# Altium schematic sheet 20-002314-00 Pg6 Interface 2.SchDoc
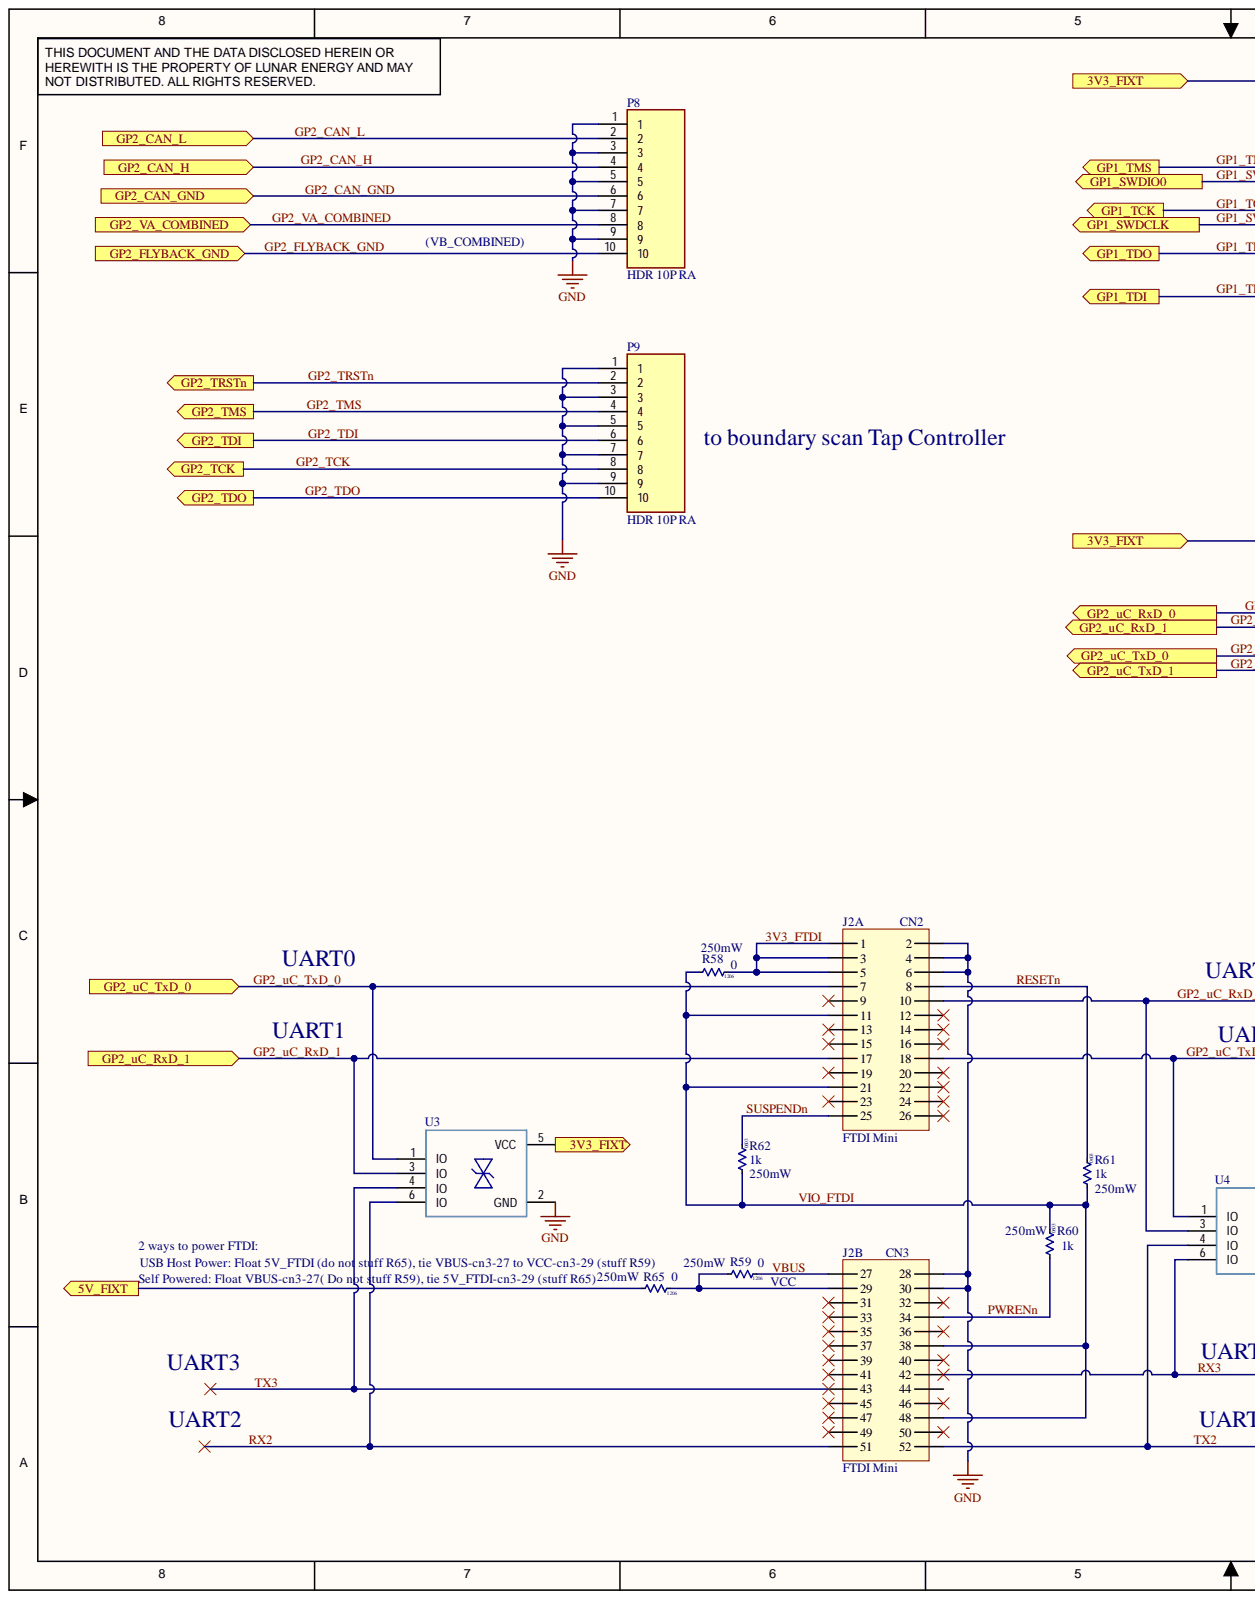
[diagram p0: 20-002314-00 Pg6 Interface 2.SchDoc, left]
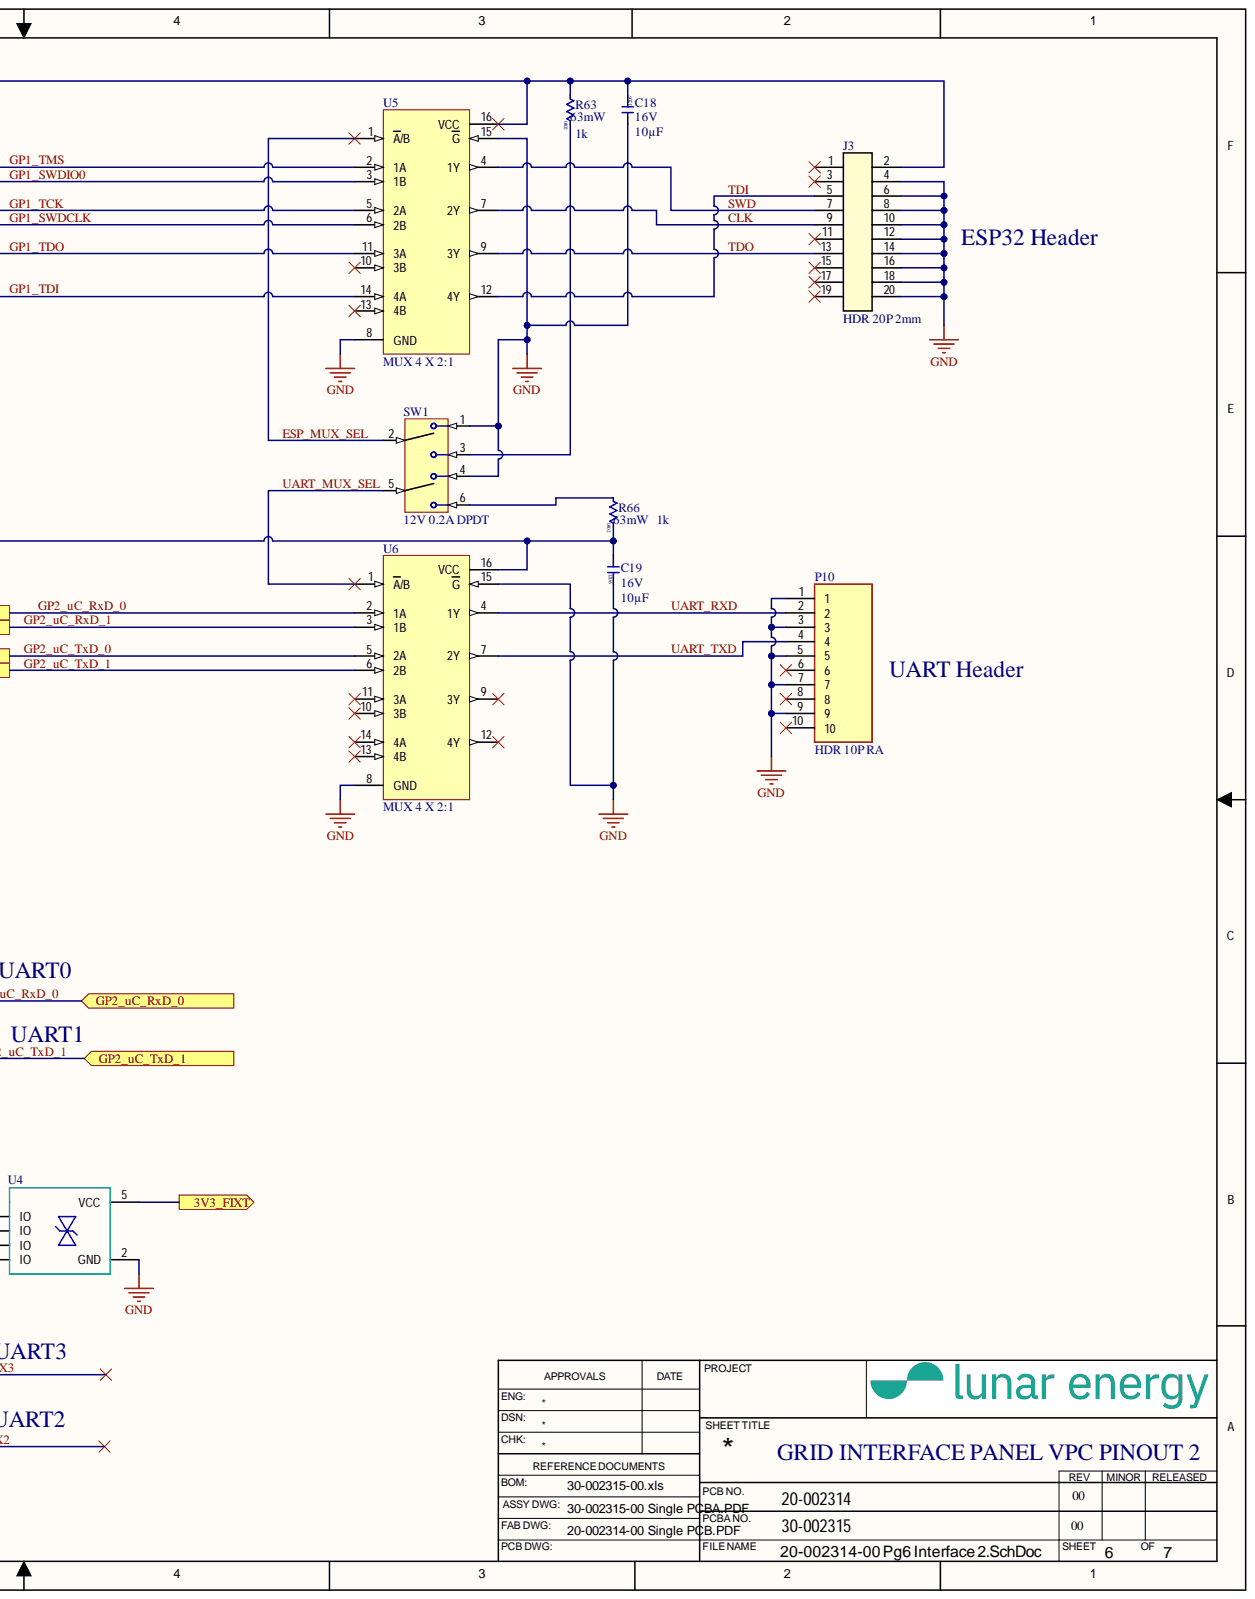
[diagram p1: 20-002314-00 Pg6 Interface 2.SchDoc, right]

## Parts
C18  value 10µF  Rated DC Voltage (URdc): 16V  Size Code: 1206  [CAPC1206 - Lunar]  (hidden comment: CNC5L1X7R1C106K160AE)
C19  value 10µF  Rated DC Voltage (URdc): 16V  Size Code: 1206  [CAPC1206 - Lunar]  (hidden comment: CNC5L1X7R1C106K160AE)
J2  Lunar Sym Name: FTDI Mini  [FT4232H MINI MODULE]  (hidden comment: FT4232H MINI MODULE)  (parts A,B)
J3  Lunar Sym Name: HDR 20P 2mm  [CONN HDR 20P 2mm-Footprint-1]  (hidden comment: CONN HDR 20P 2mm)
P8  Lunar Sym Name: HDR 10P RA  [CONN HDR RA 10POS 2.54MM]  (hidden comment: CONN HDR RA 10POS 2.54MM)
P9  Lunar Sym Name: HDR 10P RA  [CONN HDR RA 10POS 2.54MM]  (hidden comment: CONN HDR RA 10POS 2.54MM)
P10  Lunar Sym Name: HDR 10P RA  [CONN HDR RA 10POS 2.54MM]  (hidden comment: CONN HDR RA 10POS 2.54MM)
R58  value 0  Case/Package: 1206  Power: 250mW  [RES1206 - Lunar]  (hidden comment: RMCF1206ZT0R00)
R59  value 0  Case/Package: 1206  Power: 250mW  [RES1206 - Lunar]  (hidden comment: RMCF1206ZT0R00)
R60  value 1k  Rated Power Dissipation (P): 250mW  Resistance: 1k  Size Code: 0603  [R template test-Footprint-1]  (hidden comment: R 0603 1K OHM 1/4W)
R61  value 1k  Rated Power Dissipation (P): 250mW  Resistance: 1k  Size Code: 0603  [R template test-Footprint-1]  (hidden comment: R 0603 1K OHM 1/4W)
R62  value 1k  Rated Power Dissipation (P): 250mW  Resistance: 1k  Size Code: 0603  [R template test-Footprint-1]  (hidden comment: R 0603 1K OHM 1/4W)
R63  value 1k  Case/Package: 0402  Rated Power Dissipation (P): 63mW  Resistance: 1k  [RES 0402 - Lunar]  (hidden comment: R 0402 1K OHM 1/16W)
R65  value 0  Case/Package: 1206  Power: 250mW  [RES1206 - Lunar]  (hidden comment: RMCF1206ZT0R00)
R66  value 1k  Case/Package: 0402  Rated Power Dissipation (P): 63mW  [RES 0402 - Lunar]  (hidden comment: R 0402 1K OHM 1/16W)
SW1  Lunar Sym Name: 12V 0.2A DPDT  Throw Configuration: DPDT  [SWITCH SLIDE DPDT 200MA 12V-Footprint-1]  (hidden comment: SWITCH SLIDE DPDT 200MA 12V)
U3  DT1446  [TSOT26]  (value not drawn)
U4  DT1446  [TSOT26]  (value not drawn)
U5  Lunar Sym Name: MUX 4 X 2:1  [DB0016A_M]  (hidden comment: IC MUX 4 X 2:1)
U6  Lunar Sym Name: MUX 4 X 2:1  [DB0016A_M]  (hidden comment: IC MUX 4 X 2:1)

## Ports
3V3_FIXT, 5V_FIXT, GP1_SWDCLK, GP1_SWDIO0, GP1_TCK, GP1_TDI, GP1_TDO, GP1_TMS, GP2_CAN_GND, GP2_CAN_H, GP2_CAN_L, GP2_FLYBACK_GND, GP2_TCK, GP2_TDI, GP2_TDO, GP2_TMS, GP2_TRSTn, GP2_uC_RxD_0, GP2_uC_RxD_1, GP2_uC_TxD_0, GP2_uC_TxD_1, GP2_VA_COMBINED

## Nets
GND: C18.2 C19.1 J2.2 J2.4 J2.6 J2.28 J2.30 J3.4 J3.6 J3.8 J3.10 J3.12 J3.14 J3.16 J3.18 J3.20 P8.1 P8.3 P8.5 P8.7 P8.9 P9.1 P9.3 P9.5 P9.7 P9.9 P10.1 P10.3 P10.5 P10.7 P10.9 SW1.1 SW1.4 U3.2(GND) U4.2(GND) U5.8(GND) U5.15(G) U6.8(GND) U6.15(G)
3V3_FIXT: C18.1 C19.2 J3.2 R63.1 R66.2 U3.5(VCC) U4.5(VCC) U5.16(VCC) U6.16(VCC) ; port 3V3_FIXT
3V3_FTDI_6: J2.1 J2.3 J2.5 R58.2  [also labelled 3V3_FTDI]
5V_FIXT: R65.1 ; port 5V_FIXT
CLK_6: J3.9 U5.7(2Y)  [also labelled CLK]
ESP_MUX_SEL_6: SW1.2 U5.1(A/B)  [also labelled ESP_MUX_SEL]
GP1_SWDCLK: U5.6(2B) ; port GP1_SWDCLK
GP1_SWDIO0: U5.3(1B) ; port GP1_SWDIO0
GP1_TCK: U5.5(2A) ; port GP1_TCK
GP1_TDI: U5.14(4A) ; port GP1_TDI
GP1_TDO: U5.11(3A) ; port GP1_TDO
GP1_TMS: U5.2(1A) ; port GP1_TMS
GP2_CAN_GND: P8.6 ; port GP2_CAN_GND
GP2_CAN_H: P8.4 ; port GP2_CAN_H
GP2_CAN_L: P8.2 ; port GP2_CAN_L
GP2_FLYBACK_GND: P8.10 ; port GP2_FLYBACK_GND
GP2_TCK: P9.8 ; port GP2_TCK
GP2_TDI: P9.6 ; port GP2_TDI
GP2_TDO: P9.10 ; port GP2_TDO
GP2_TMS: P9.4 ; port GP2_TMS
GP2_TRSTn: P9.2 ; port GP2_TRSTn
GP2_uC_RxD_0: J2.10 U4.3(IO) U6.2(1A) ; port GP2_uC_RxD_0
GP2_uC_RxD_1: J2.17 U3.3(IO) U6.3(1B) ; port GP2_uC_RxD_1
GP2_uC_TxD_0: J2.7 U3.1(IO) U6.5(2A) ; port GP2_uC_TxD_0
GP2_uC_TxD_1: J2.18 U4.1(IO) U6.6(2B) ; port GP2_uC_TxD_1
GP2_VA_COMBINED: P8.8 ; port GP2_VA_COMBINED
PWRENn_6: J2.34 R60.1  [also labelled PWRENn]
RESETn_6: J2.8 R61.2  [also labelled RESETn]
RX2_6: J2.51 U3.6(IO)  [also labelled RX2]
RX3_6: J2.42 U4.6(IO)  [also labelled RX3]
SUSPENDn_6: J2.25 R62.2  [also labelled SUSPENDn]
SWD_6: J3.7 U5.4(1Y)  [also labelled SWD]
TDI_6: J3.5 U5.12(4Y)  [also labelled TDI]
TDO_6: J3.13 U5.9(3Y)  [also labelled TDO]
TX2_6: J2.52 U4.4(IO)  [also labelled TX2]
TX3_6: J2.43 U3.4(IO)  [also labelled TX3]
UART_MUX_SEL_6: SW1.5 U6.1(A/B)  [also labelled UART_MUX_SEL]
UART_RXD_6: P10.2 U6.4(1Y)  [also labelled UART_RXD]
UART_TXD_6: P10.4 U6.7(2Y)  [also labelled UART_TXD]
VBUS_6: J2.27 R59.2  [also labelled VBUS]
VIO_FTDI_6: J2.11 J2.21 J2.38 J2.48 R58.1 R60.2 R61.1 R62.1  [also labelled VIO_FTDI]
NetJ2_29: J2.29 R59.1 R65.2
NetR63_2: R63.2 SW1.3
NetR66_1: R66.1 SW1.6

Not connected: J2 9 12 13 14 15 16 19 20 22 23 24 26 31 32 33 35 36 37 39 40 41 44 45 46 47 49 50; J3 1 3 11 15 17 19; P10 6 8 10; U5 10(3B) 13(4B); U6 9(3Y) 10(3B) 11(3A) 12(4Y) 13(4B) 14(4A)

## Library data of the parts
C18 C19 = CMP-001-00049-10: Ceramic Capacitor, Multilayer, Ceramic, 16V, 10% +Tol, 10% -Tol, X7R, 15% TC, 10uF, Surface Mount, 1206; Manufacturer: TDK; Lunar PN: 10-001736; Multilayer: Yes; Number of Terminals/Pins: 2; Production Intent: NO; Capacitance: 10uF; Capacitor Type: Ceramic; Component Kind: Standard; Dielectric Material: Ceramic; Height: 1.6mm; Length: 3.2mm; Manufacturer PN: CNC5L1X7R1C106K160AE; Max Operating Temperature: 125°C; Min Operating Temperature: -55°C; Mount: Surface Mount; Negative Tolerance: 10%; Package Style: SMTMeter; Packaging: Tape and Reel; Positive Tolerance: 10%; REACH SVHC: Yes; RoHS Compliant: Yes; Temperature Characteristics Code: X7R; Termination: Wraparound; Voltage Rating: 16V; Width: 1.6mm; Library Name: Lunar Energy
J2 = CMP-00030-00030-1: FTDI - FT4232H MINI MODULE - Entwicklungsmodul, USB zu Serial-MPSSE, Erweitern des Zieldesigns um USB, 4 Schnittstellen; Manufacturer: FTDI, Future Technology Devices International Ltd; Lunar PN: 10-002542; Production Intent: YES; Component Kind: Standard; Manufacturer PN: FT4232H MINI MODULE; Library Name: Lunar Energy
J3 = CMP-002-00105-6: 5016452020 CONN HEADER VERT 20POS 2MM; Manufacturer: Molex; Lunar PN: 10-001240; Production Intent: YES; Component Kind: Standard; Manufacturer PN: 5016452020; Library Name: Lunar Energy
P8-P10 = CMP-002-00165-1: TSW-110-08-G-S-RA, Board Connector, 10 Contact(s), 1 Row(s), Male, Right Angle, 0.1 inch Pitch, Solder Terminal, Black Insulator; Manufacturer: Samtec Inc.; Contact Pattern: RECTANGULAR; Contact Style: SQ PIN-SKT; DIN Conformance: No; Lunar PN: 10-002298; Mating Information: MULTIPLE MATING PARTS AVAILABLE; Number Of Connectors: 1; Number of Contacts: 10; Option: General Purpose; Plating Thickness: 10uin; Production Intent: YES; Reference Standard: UL; Reliability: COMMERCIAL; Body Breadth: 0.1inch; Body Depth: 0.1inch; Component Kind: Standard; Connector Type: BOARD CONNECTOR; Contact Finish - Mating: GOLD (10) OVER NICKEL (50); Contact Finish - Termination: Gold (Au) - with Nickel (Ni) barrier; Contact Gender: Male; Contact Material: Bronze; Filter Feature: No; IEC Conformance: No; Insulation Color: Black; Insulation Material: Polybutylene; JESD-609 Code: e4; Manufacturer PN: TSW-110-08-G-S-RA; Mating Contact Pitch: 0.1inch; MIL Conformance: No; Mixed Contacts: No; Mount: Board; Mounting Style: RIGHT ANGLE; Number Of PCB Rows: 1; Number of Rows Loaded: 1; PCB Contact Pattern: RECTANGULAR; REACH SVHC: Yes; RoHS Compliant: Yes; Terminal Length: 0.09inch; Terminal Pitch: 2.54mm; Termination: Solder; Library Name: Lunar Energy
R58 R59 R65 = CMP-009-00086-4: Fixed Resistor, Metal Glaze/thick Film, 0.25W, 0ohm, Surface Mount, 1206; Manufacturer: Stackpole Electronics; AEC-Q: Yes; Lunar PN: 10-001704; Notes: 2; Component Kind: Standard; Manufacturer PN: RMCF1206ZT0R00; Max Operating Temperature: 155°C; Min Operating Temperature: -55°C; Mounting Technology: SMT; Production Intent: YES; RoHS Compliant: Yes; Library Name: Lunar Energy
R60-R62 = CMP-00073-4: Fixed Resistor, Metal Glaze/thick Film, 0.25W, 1000ohm, 150V, 1% +/-Tol, 100ppm/Cel, Surface Mount, 0603; Manufacturer: Panasonic Electronic Components; Manufacturer PN: ERJ-PA3F1001V; Lunar PN: 10-000999; Number of Terminals/Pins: 2; Package Height: 0.45mm; Package Length: 1.6mm; Package Width: 0.8mm; Production Intent: YES; Rated Temperature: 70°C; Reference Standard: AEC-Q200; Temperature Coefficient: 100ppm/°C; Component Kind: Standard; Construction: Rectangular; JESD-609 Code: e3; Max Operating Temperature: 155°C; Min Operating Temperature: -55°C; Mount: Surface Mount; Mounting Technology: SMT; Package Shape: Rectangular; Package Style: SMTMeter; Packaging: Tape and Reel; REACH SVHC: Yes; Resistor Type: FIXED RESISTOR; RoHS Compliant: Yes; Supplier: Digikey; Supplier PN: P1.0KBYCT-ND; Supplier Web Page URL: https://www.digikey.com/en/products/detail/panasonic-electronic-components/ERJ-PA3F1001V/5035848; Technology: METAL GLAZE/THICK FILM; Terminal Finish: Tin; Termination: Wraparound; Tolerance: 1%; Working Voltage: 150V; Library Name: Lunar Energy
R63 R66 = CMP-009-00108-7: CRCW04021K00FKED  Fixed Resistor, Metal Glaze/thick Film, 0.063W, 1000ohm, 50V, 1% +/-Tol, 100ppm/Cel, Surface Mount, 0402; Manufacturer: Vishay; Lunar PN: 10-001023; Number of Terminals/Pins: 2; Package Height: 0.35mm; Package Length: 1mm; Package Width: 0.5mm; Production Intent: YES; Rated Temperature: 70°C; Reference Standard: AEC-Q200; Temperature Coefficient: 100ppm/°C; Component Kind: Standard; Construction: Chip; JESD-609 Code: e3; Lunar Create Date: 09/06/2021; Lunar Datasheet Depository Path: https://drive.google.com/drive/folders/1AESS4IK8MkrmWyBxSe5BEtJmOHfpuyJ2; Manufacturer PN: CRCW04021K00FKED; Max Operating Temperature: 155°C; Min Operating Temperature: -55°C; Mount: Surface Mount; Package Shape: Rectangular; Package Style: SMTMeter; Packaging: Tape and Reel; REACH SVHC: Yes; Resistor Type: FIXED RESISTOR; RoHS Compliant: Yes; Size Code: 0402; Supplier: Digikey; Supplier PN: 541-1.00KLCT-ND; Supplier Web Page URL: https://www.digikey.com/en/products/detail/vishay-dale/CRCW04021K00FKED/1178007; Technology: METAL GLAZE/THICK FILM; Terminal Finish: Nickel; Termination: Wraparound; Tolerance: 1%; Working Voltage: 50V; Library Name: Lunar Energy
  R66: Resistance: 1k
SW1 = CMP-011-00004-4: CL-SB-22A-02T, Slide Switch, DPDT, Latched, 0.2A, 12VDC, Solder Terminal, Surface Mount-straight; Manufacturer: Nidec Copal Electronics; Lunar PN: 10-001984; Production Intent: YES; Component Kind: Standard; Current Rating: 200mA; Manufacturer PN: CL-SB-22A-02T; Max Current Rating: 200mA; Max Operating Temperature: 85°C; Min Operating Temperature: -40°C; Mounting Technology: SMT; RoHS Compliant: Yes; Library Name: Lunar Energy
U3 U4 = CMP-004-00048-2: TVS DIODE 5V 8.5V TSOT-26, HDMI, 19KV / 16KV; Manufacturer: Diodes Inc; Manufacturer PN: DT1446-04TS-7; Lunar PN: 10-002462; Production Intent: YES; Component Kind: Standard; Design Item ID: DT1446; Source: Home Controller Motherboard.SCHLIB; Library Name: Lunar Energy
U5 U6 = CMP-031-00033-2: Multiplexer, AHC/VHC/H/U/V Series, 4-Func, 2 Line Input, 1 Line Output, True Output, CMOS, PDSO16; Manufacturer: Texas Instruments; Manufacturer PN: SN74AHC157DBR; Lunar PN: 10-001096; Production Intent: YES; Component Kind: Standard; Max Operating Temperature: 125°C; Min Operating Temperature: -40°C; RoHS Compliant: Yes; Library Name: Lunar Energy
